# Altium schematic sheet [1] - POWER SUPPLY.SchDoc
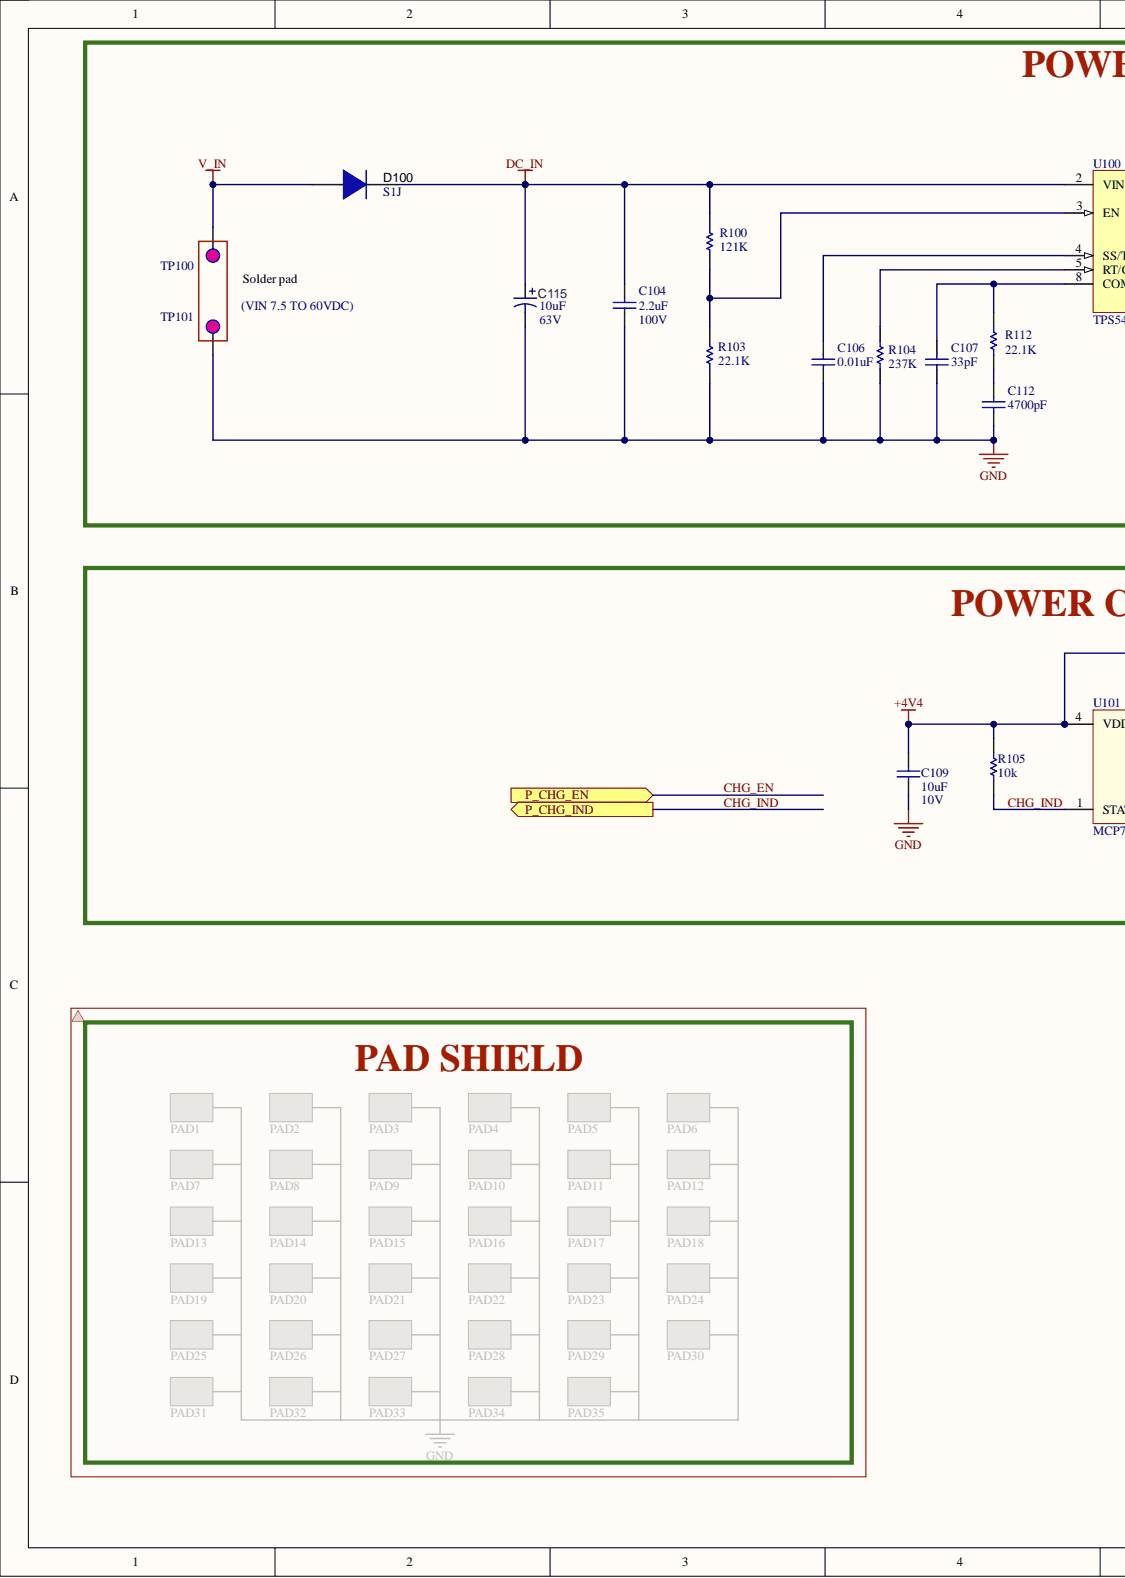
[diagram p0: [1] - POWER SUPPLY.SchDoc, left]
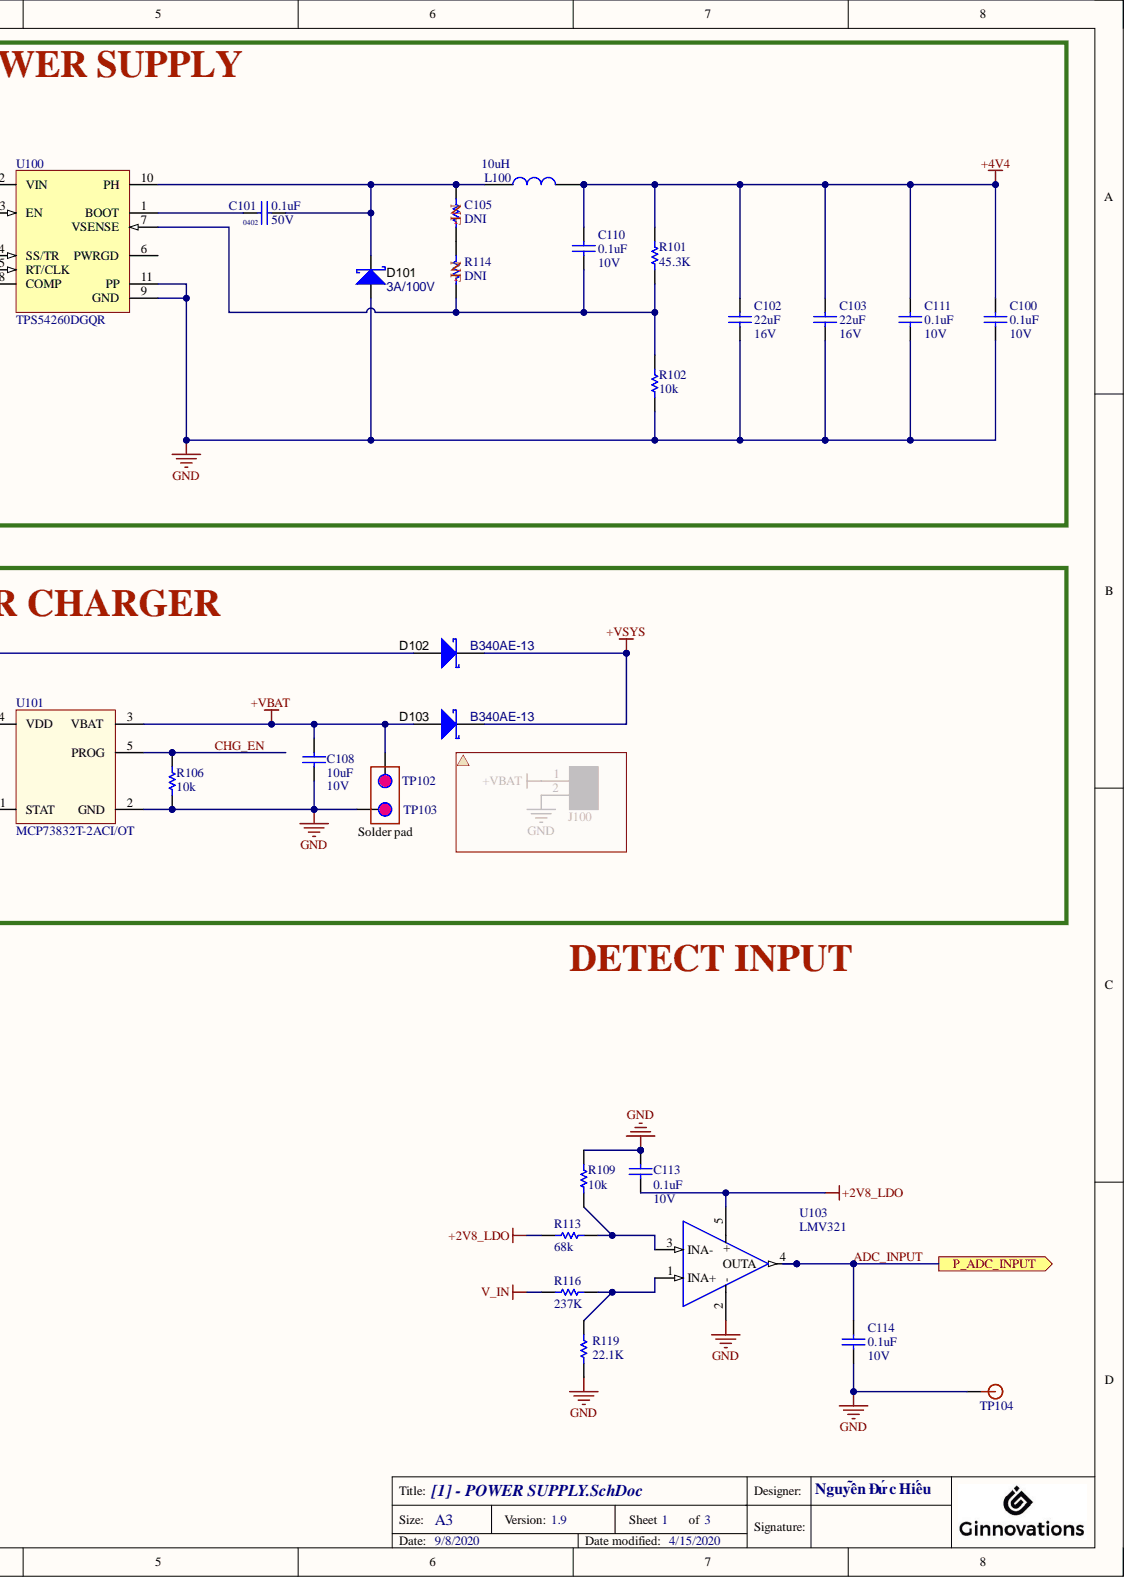
[diagram p1: [1] - POWER SUPPLY.SchDoc, right]

## Parts
C100  0.1uF  Value2: 10V  [C0402L]
C101  0.1uF  Case / Package: 0402  Value2: 50V  [C0402L]
C102  22uF  Value2: 16V  [C0805Z]
C103  22uF  Value2: 16V  [C0805Z]
C104  2.2uF  Value2: 100V  [C1206L]
C105  DNI  [DNI]  (no BOM)
C106  0.01uF  [C0402z]
C107  33pF  [C0402z]
C108  10uF  Value2: 10V  [C0603Z]
C109  10uF  Value2: 10V  [C0603Z]
C110  0.1uF  Value2: 10V  [C0402L]
C111  0.1uF  Value2: 10V  [C0402L]
C112  4700pF  [C0402z]
C113  0.1uF  Value2: 10V  [C0402L]
C114  0.1uF  Value2: 10V  [C0402L]
C115  10uF  Value2: 63V  [CAP 5X11MM]
D100  S1J  [SMA]
D101  3A/100V  [SMA]
D102  B340AE-13  [SMA]
D103  B340AE-13  [SMA]
L100  value 10uH  [IND6x6x2.8]  (hidden comment: NRS6028T100MMGK)
R100  121K  [R0402L]
R101  45.3K  [R0402L]
R102  10k  [R0402L]
R103  22.1K  [R0402L]
R104  237K  [R0402L]
R105  10k  [R0402L]
R106  10k  [R0402L]
R109  10k  [R0402L]
R112  22.1K  [R0402L]
R113  68k  [R0402L]
R114  DNI  [DNI]  (no BOM)
R116  237K  [R0402L]
R119  22.1K  [R0402L]
TP100  Testpoint 2  [TESTPOINT 1.2MM]  (value not drawn)  (no BOM)
TP101  Testpoint 2  [TESTPOINT 1.2MM]  (value not drawn)  (no BOM)
TP102  Testpoint 2  [TESTPOINT 1.2MM]  (value not drawn)  (no BOM)
TP103  Testpoint 2  [TESTPOINT 1.2MM]  (value not drawn)  (no BOM)
TP104  2.54  [TESTPOINT 1.2mm]  (value not drawn)  (no BOM)
U100  TPS54260DGQR  [DGQ0010D_N]
U101  MCP73832T-2ACI/OT  [SOT23-5Z]
U103  LMV321  [SOT23-5Z]

## Ports
P_ADC_INPUT, P_CHG_EN, P_CHG_IND

## Nets
+2V8_LDO: C113.1 R113.1 U103.5(+)
+4V4: C100.1 C102.1 C103.1 C109.1 C110.1 C111.1 D102.2 L100.2 R101.2 R105.2 U101.4(VDD)
+VBAT: C108.1 D103.2 TP102.1 U101.3(VBAT)
+VSYS: D102.1 D103.1
DC_IN: C104.1 C115.1 D100.1 R100.2 U100.2(VIN)
GND: C100.2 C102.2 C103.2 C104.2 C106.1 C107.1 C108.2 C109.2 C111.2 C112.1 C113.2 C114.2 C115.2 D101.2 R102.1 R103.1 R104.1 R106.1 R109.2 R119.1 TP101.1 TP103.1 TP104.1 U100.9(GND) U100.11(PP) U101.2(GND) U103.2(-)
V_IN: D100.2 R116.2 TP100.1
ADC_INPUT: C114.1 U103.4(OUTA) ; port P_ADC_INPUT
CHG_EN: R106.2 U101.5(PROG) ; port P_CHG_EN
CHG_IND: R105.1 U101.1(STAT) ; port P_CHG_IND
NetC101_1: C101.1 U100.1(BOOT)
NetC101_2: C101.2 C105.1 D101.1 L100.1 U100.10(PH)
NetC105_2: C105.2 R114.1
NetC106_2: C106.2 U100.4(SS/TR)
NetC107_2: C107.2 R112.2 U100.8(COMP)
NetC110_2: C110.2 R101.1 R102.2 R114.2 U100.7(VSENSE)
NetC112_2: C112.2 R112.1
NetR100_1: R100.1 R103.2 U100.3(EN)
NetR104_2: R104.2 U100.5(RT/CLK)
NetR109_1: R109.1 R113.2 U103.3(INA-)
NetR116_1: R116.1 R119.2 U103.1(INA+)

Not connected: U100 6(PWRGD)

## Library data of the parts
C100 C110 C111 C113 C114 = C0402: CAP CER 0402; Manufacturer: Murata Electronics; Manufacturer Part Number: GRM155R71A104KA01D; Code HW: EAPCA00006; Comment Description: Multilayer Ceramic Capacitors MLCC - SMD/SMT 0402 0.1uF 10volts X7R 10%; Tolerance: 10%; Types: Capacitor; Case / Package: 0402; Component Kind: Standard; Ginno Items Number Stock: N/A; Ginno Part Number: N/A; Mounting Type: Surface Mount; Price: N/A; Value: 0.1uF; Library Name: Xphone Rev2.4.SCHLIB
C101 = C0402: CAP CER 0402; Manufacturer: Murata Electronics; Manufacturer Part Number: C1005X7R1H104M050BB; Code HW: EAPCA00084; Comment Description: Multilayer Ceramic Capacitors MLCC - SMD/SMT 0402 50V 0.1uF X7R 20% T: 0.5mm; Tolerance: 20%; Types: Capacitor; Component Kind: Standard; Ginno Items Number Stock: N/A; Ginno Part Number: N/A; Mounting Type: Surface Mount; Price: N/A; Value: 0.1uF; Library Name: Ginno.SCHLIB
C102 C103 = C0402: CAP CER 0402; Manufacturer: Samsung; Manufacturer Part Number: CL21A226MOCLRNC; Code HW: EAPCA00026; Comment Description: CAP CER 22UF 16V X5R 0805; Tolerance: 20%; Types: Capacitor; Case / Package: 0805; Component Kind: Standard; Ginno Items Number Stock: N/A; Ginno Part Number: N/A; Mounting Type: Surface Mount; Price: N/A; Value: 22uF; Library Name: Xphone Rev2.4.SCHLIB
C104 = C1206: CAP CER 1206; Manufacturer: Taiyo Yuden; Manufacturer Part Number: HMK316AC7225KL-TE; Code HW: EAPCA00024; Comment Description: CAP CER 2.2UF 100V X7S 1206; Tolerance: 10%; Types: Capacitor; Case / Package: 1206; Component Kind: Standard; Ginno Items Number Stock: N/A; Ginno Part Number: N/A; Mounting Type: Surface Mount; Price: N/A; Value: 2.2uF; Library Name: Ginno.SCHLIB
C106 = C0402: CAP CER 0402; Manufacturer: Samsung Electro-Mechanics; Manufacturer Part Number: CL05B103KA5NNNC; Code HW: EAPCA00020; Comment Description: CAP CER 10000PF 25V X7R 0402; Tolerance: 10%; Types: Capacitor; Value2: 25V; Case / Package: 0402; Component Kind: Standard; Ginno Items Number Stock: N/A; Ginno Part Number: N/A; Mounting Type: Surface Mount; Price: N/A; Value: 0.01uF; Library Name: Gtracker MP2.SCHLIB
C107 = C0402: CAP CER 0402; Manufacturer: Murata Electronics; Manufacturer Part Number: GRM1555C1E330JA01D; Code HW: EAPCA00028; Comment Description: CAP CER 33PF 25V C0G/NP0 0402; Tolerance: 20%; Types: Capacitor; Value2: 25V; Case / Package: 0402; Component Kind: Standard; Ginno Items Number Stock: N/A; Ginno Part Number: N/A; Mounting Type: Surface Mount; Price: N/A; Value: 33pF; Library Name: Ginno Passive.SchLib
C108 C109 = C0603: CAP CER 0603; Manufacturer: Taiyo Yuden; Manufacturer Part Number: LMK107BBJ106MALT; Code HW: EAPCA00025; Comment Description: CAP CER 10UF 10V X5R 0603; Tolerance: 20%; Types: Capacitor; Case / Package: 0603; Component Kind: Standard; Ginno Items Number Stock: N/A; Ginno Part Number: N/A; Mounting Type: Surface Mount; Price: N/A; Value: 10uF; Library Name: Xphone Rev2.4.SCHLIB
C112 = C0402: CAP CER 0402; Manufacturer: Murata Electronics; Manufacturer Part Number: GCM155R71H472KA37D; Code HW: EAPCA00030; Comment Description: CAP CER 4700PF 50V X7R 0402; Tolerance: 10%; Types: Capacitor; Value2: 50V; Case / Package: 0402; Component Kind: Standard; Ginno Items Number Stock: N/A; Ginno Part Number: N/A; Mounting Type: Surface Mount; Price: N/A; Value: 4700pF; Library Name: Ginno Passive.SchLib
C115 = CAP POL: CAP ALUM 10UF 20% 100V RADIAL; Manufacturer: Panasonic Electronic Components; Manufacturer Part Number: EEU-FS1J100B; Code HW: EAPCA00085; Comment Description: CAP ALUM 10UF 20% 63V THRU HOLE; Types: Capacitor; Case / Package: Radial, Can; Component Kind: Standard; Ginno Items Number Stock: N/A; Ginno Part Number: N/A; Price: N/A; Signal Integrity: Cap; Simulation: Cap; Tolerance: 20%; Value: 10uF; Library Name: Ginno.SCHLIB
D100 = 1N4148WSTR: DIODE GEN PURP 75V 300MA SOD323; Manufacturer: ON Semiconductor; Code HW: EAPDO00008; Comment Description: DIODE GEN PURP 600V 1A SMA; Case / Package: SMA; Component Kind: Standard; Ginno Items Number Stock: N/A; Ginno Part Number: N/A; Manufacturer Part Number: S1J; Mounting Type: Surface Mount; Price: N/A; Signal Integrity: Diode; Simulation: 1N4148W; Tolerance: N/A; Types: Diode; Value: S1J; Value2: N/A; Library Name: Ginno.SCHLIB
D101 = SK310A-LTP: DIODE SCHOTTKY 100V 3A DO214AC; Manufacturer: ON Semiconductor; Manufacturer Part Number: NRVTSA4100ET3G; Code HW: EAPDO00006; Comment Description: DIODE SCHOTTKY 100V 4A SMA; Value: NRVTSA4100ET3G; Case / Package: N/A; Component Kind: Standard; Ginno Items Number Stock: N/A; Ginno Part Number: N/A; Mounting Type: Surface Mount; Price: N/A; Tolerance: N/A; Types: Diode; Value2: N/A; Library Name: Ginno.SCHLIB
D102 D103 = NSR05F40NXT5G: Diode, Schottky, 40V, 500A, DSN2; Manufacturer: Diodes Incorporated; Code HW: EAPDO00005; Comment Description: DIODE SCHOTTKY 40V 2A SMA; Case / Package: N/A; Component Kind: Standard; Ginno Items Number Stock: N/A; Ginno Part Number: N/A; Manufacturer Part Number: B340AE-13; Mounting Type: Surface Mount; Price: N/A; Tolerance: N/A; Types: Diode; Value: B340AE-13; Value2: N/A; Library Name: Xphone Rev2.4.SCHLIB
L100 = IND 0805: Manufacturer: Taiyo Yuden; Code HW: EAPIN00007; Comment Description: FIXED IND 10UH 1.9A 84.5 MOHM; Types: Inductor; Case / Package: N/A; Component Kind: Standard; Ginno Items Number Stock: N/A; Ginno Part Number: N/A; Library Name: mLib.SchLib; Manufacturer Part Number: NRS6028T100MMGK; Mounting Type: Surface Mount; Price: N/A; Tolerance: N/A
R100 = R0402: RES SMD 0402; Manufacturer: Panasonic; Manufacturer Part Number: ERJ-2RKF1213X; Code HW: EAPRE00016; Comment Description: RES SMD 121K OHM 1% 1/10W 0402; Tolerance: 1%; Types: Resistor; Value2: 1/16W; Case / Package: 0402; Component Kind: Standard; Ginno Items Number Stock: N/A; Ginno Part Number: N/A; Mounting Type: Surface Mount; Price: N/A; Value: 121K; Library Name: JigTest_BGA100_REV1.0.SCHLIB
R101 = R0402: RES SMD 0402; Manufacturer: Panasonic; Manufacturer Part Number: ERJ-2RKF4532X; Code HW: EAPRE00015; Comment Description: RES SMD 45.3K OHM 1% 1/10W 0402; Tolerance: 1%; Types: Resistor; Value2: 1/10W; Case / Package: 0402; Component Kind: Standard; Ginno Items Number Stock: N/A; Ginno Part Number: N/A; Mounting Type: Surface Mount; Price: N/A; Value: 45.3K; Library Name: JigTest_BGA100_REV1.0.SCHLIB
R102 R105 R106 R109 = R0402: RES SMD 0402; Manufacturer: Panasonic; Manufacturer Part Number: ERJ-2RKF1002X; Code HW: EAPRE00013; Comment Description: RES SMD 10K OHM 1% 1/10W 0402; Tolerance: 1%; Types: Resistor; Value2: 1/10W; Case / Package: 0402; Component Kind: Standard; Ginno Items Number Stock: N/A; Ginno Part Number: N/A; Mounting Type: Surface Mount; Price: N/A; Value: 10k
  R102: Library Name: JigTest_BGA100_REV1.0.SCHLIB
  R105 R106 R109: Library Name: Ginno.SCHLIB
R103 R112 R119 = R0402: RES SMD 0402; Manufacturer: Vishay Dale; Manufacturer Part Number: CRCW040222K1FKEDC; Code HW: EAPRE00014; Comment Description: RES SMD 22.1K OHM 1% 1/16W 0402; Tolerance: 1%; Types: Resistor; Value2: 1/16W; Case / Package: 0402; Component Kind: Standard; Ginno Items Number Stock: N/A; Ginno Part Number: N/A; Mounting Type: Surface Mount; Price: N/A; Value: 22.1K; Library Name: JigTest_BGA100_REV1.0.SCHLIB
R104 R116 = R0402: RES SMD 0402; Manufacturer: Panasonic; Manufacturer Part Number: ERJ-2RKF2373X; Code HW: EAPRE00018; Comment Description: RES SMD 237K OHM 1% 1/10W 0402; Tolerance: 1%; Types: Resistor; Value2: 1/16W; Case / Package: 0402; Component Kind: Standard; Ginno Items Number Stock: N/A; Ginno Part Number: N/A; Mounting Type: Surface Mount; Price: N/A; Value: 237K; Library Name: JigTest_BGA100_REV1.0.SCHLIB
R113 = R0402: RES SMD 0402; Manufacturer: Panasonic; Manufacturer Part Number: ERJ-2GEJ683X; Code HW: EAPRE00006; Comment Description: RES SMD 68K OHM 5% 1/10W 0402; Ginno Items Number Stock: NA; Tolerance: 5%; Types: Resistor; Value2: 1/10W; Case / Package: 0402; Component Kind: Standard; Ginno Part Number: N/A; Library Name: Ginno.SCHLIB; Mounting Type: Surface Mount; Price: N/A; Value: 68k
TP100-TP103 = Testpoint 2: Types: TP; Case / Package: N/A; Ginno Items Number Stock: N/A; Ginno Part Number: N/A; Manufacturer: N/A; Manufacturer Part Number: N/A; Mounting Type: Surface Mount; Price: N/A; Tolerance: N/A; Value: N/A; Value2: N/A; Library Name: GTRACKER REV1.2.SCHLIB
TP104 = TESTPOINT 5000: TEST POINT PC MINI .040"D RED; Types: TP; Ginno Items Number Stock: N/A; Ginno Part Number: N/A; Library Name: mLib.SchLib; Manufacturer: N/A; Manufacturer Part Number: N/A; Mounting Type: Surface Mount; Price: N/A; Tolerance: N/A; Value: N/A; Value2: N/A
U100 = CMP-0323-00512-3: Buck Inverting Buck-Boost Step Down Regulator with 3.5 to 60 V Input and 0.8 to 58 V Output, -40 to 150 degC, 10-Pin MSOP-PowerPAD (DGQ), Green (RoHS & no Sb/Br); Manufacturer: Texas Instruments; Code HW: EAPIC00030; Types: IC; Case / Package: TSOP-10; Comment Description: Buck Inverting Buck-Boost Step Down Regulator with 3.5 to 60 V Input and 0.8 to 58 V Output, -40 to 150 degC, 10-Pin MSOP-PowerPAD (DGQ), Green (RoHS & no Sb/Br); Component Kind: Standard; Ginno Items Number Stock: N/A; Ginno Part Number: N/A; Library Name: Altium Content Vault; Manufacturer Part Number: TPS54260DGQR; Mounting Type: Surface Mount; Price: N/A; Tolerance: N/A; Value: TPS54260DGQR; Value2: N/A
U101 = MCP73832T-2ACI/OT: MICROCHIP         MCP73832T-2ACI/OT             Battery Charger for 1 Cell of Li-Ion, Li-Pol battery, 6V input, 4.2V / 500mA charge, SOT-23-5; Code HW: EAPIC00027; Comment Description: IC LI-ION/LI-POLY CTRLR SOT23-5; Types: IC; Case / Package: SOT-23-5; Component Kind: Standard; Ginno Items Number Stock: N/A; Ginno Part Number: N/A; Manufacturer: Microchip; Manufacturer Part Number: MCP73832T-2ACI/OT; Mounting Type: Surface Mount; Price: N/A; Tolerance: N/A; Value: MCP73832T-2ACI/OT; Value2: N/A; Library Name: Gtracker Rev 1.8.SCHLIB
U103 = LMV321: Manufacturer: Texas Instruments; Manufacturer Part Number: LMV321IDBVR; Code HW: EAPIC00025; Comment Description: LMV3xx-N/-Q1 Single, Dual, and Quad General Purpose, Low-Voltage, Rail-to-Rail Output; Types: IC; Value: LMV321IDBVR; Case / Package: SOT-23-5; Component Kind: Standard; Ginno Items Number Stock: N/A; Ginno Part Number: N/A; Mounting Type: Surface Mount; Price: N/A; Tolerance: N/A; Value2: N/A; Library Name: Ginno.SCHLIB
C105 R114 = DNI: Ginno Items Number Stock: N/A; Ginno Part Number: N/A; Manufacturer: N/A; Manufacturer Part Number: N/A; Price: N/A; Value: DNI; Library Name: Ginno.SCHLIB
